# Altium schematic sheet PAGE06_握手协议飞机端.SchDoc
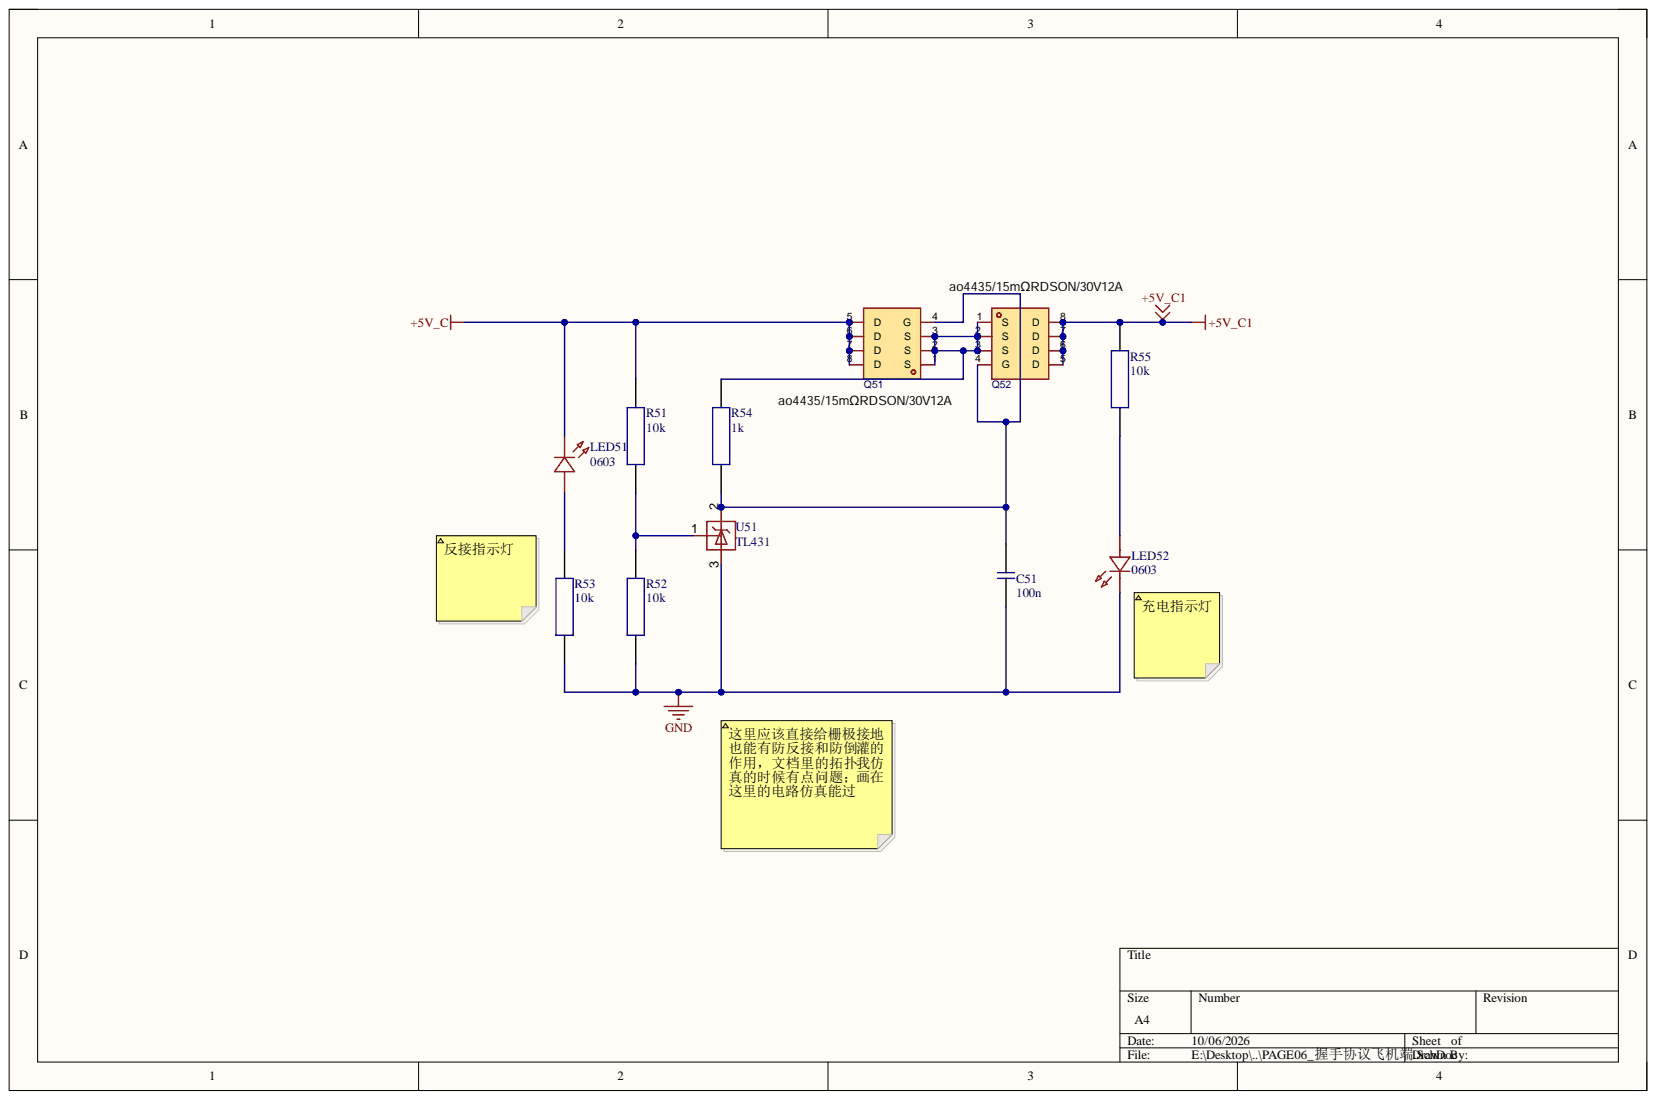
[diagram p0: PAGE06_握手协议飞机端.SchDoc]

## Parts
C51  100n  [0603_ding_C]
LED51  0603  [LED0603-RD_GREEN]
LED52  0603  [LED0603-RD_GREEN]
Q51  ao4435/15mΩRDSON/30V12A  [SOP-8_L5.0-W3.8-P1.27-LS6.0-BL]
Q52  ao4435/15mΩRDSON/30V12A  [SOP-8_L5.0-W3.8-P1.27-LS6.0-BL]
R51  10k  [0603_ding_R]
R52  10k  [0603_ding_R]
R53  10k  [0603_ding_R]
R54  1k  [0603_ding_R]
R55  10k  [0603_ding_R]
U51  TL431  [SOT-23-3_L3.0-W1.6-P1.90-LS2.8-BR]

## Nets
+5V_C: LED51.1 Q51.5(D) Q51.6(D) Q51.7(D) Q51.8(D) R51.2
+5V_C1: Q52.5(D) Q52.6(D) Q52.7(D) Q52.8(D) R55.1
GND: C51.1 LED52.1 R52.1 R53.1 U51.3
NetC51_2: C51.2 Q51.4(G) Q52.4(G) R54.1 U51.2
NetLED51_2: LED51.2 R53.2
NetLED52_2: LED52.2 R55.2
NetQ51_1: Q51.1(S) Q51.2(S) Q51.3(S) Q52.1(S) Q52.2(S) Q52.3(S) R54.2
NetR51_1: R51.1 R52.2 U51.1

## Library data of the parts
C51 = C: Component Kind: Standard; Library Name: IP6826.SchLib
LED51 LED52 = LED0603: Component Kind: Standard; Library Name: IP6826.SchLib
Q51 Q52 = ao4435: 数量:1个P沟道;漏源电压(Vdss):30V;连续漏极电流(Id):12A;导通电阻(RDS(on)):15mΩ@10V;耗散功率(Pd):2.5W;阈值电压(Vgs(th)):3V;; Manufacturer: UMW(友台半导体); AddInBom: yes; Current - Continuous Drain(Id): 12A; Drain to Source Voltage: 30V; Gate Charge(Qg): 18nC; Gate Threshold Voltage (Vgs(th)): 3V; Input Capacitance(Ciss): 1.175nF; ModelId: 823e4ad1ee284f09bfed9f55dae295e0; ModelTransform: 196.85,236.22,0,0,0,0,0,0,0; Number: 1个P沟道; Output Capacitance(Coss): 195pF; Pd - Power Dissipation: 2.5W; Reverse Transfer Capacitance (Crss@Vds): 190pF; Symbol: AO4435_C2944310; Component Kind: Standard; ConvertToPcb: yes; Datasheet: https://item.szlcsc.com/datasheet/AO4435/3330325.html; FootprintName: SOP-8_L5.0-W3.8-P1.27-LS6.0-BL; JLCPCB Part Class: Extended Part; LCSC Part Name: 1个P沟道 耐压:30V 电流:12A; Library Name: AO4435_C2944310.SCHLIB; ManufacturerPart: AO4435; ModelName: SOP-8_L5.0-W3.8-P1.27-LS6.0-BL; Operating Temperature: -55℃~+150℃; RDS(on): 15mΩ@10V; Supplier: LCSC; Supplier Footprint: SOP-8; SupplierPart: C2944310
R51-R55 = RES: Component Kind: Standard; Library Name: IP6826.SchLib
U51 = TL431: Component Kind: Standard; Library Name: IP6826.SchLib
